# Altium schematic sheet Supplies.SchDoc(Supplies)
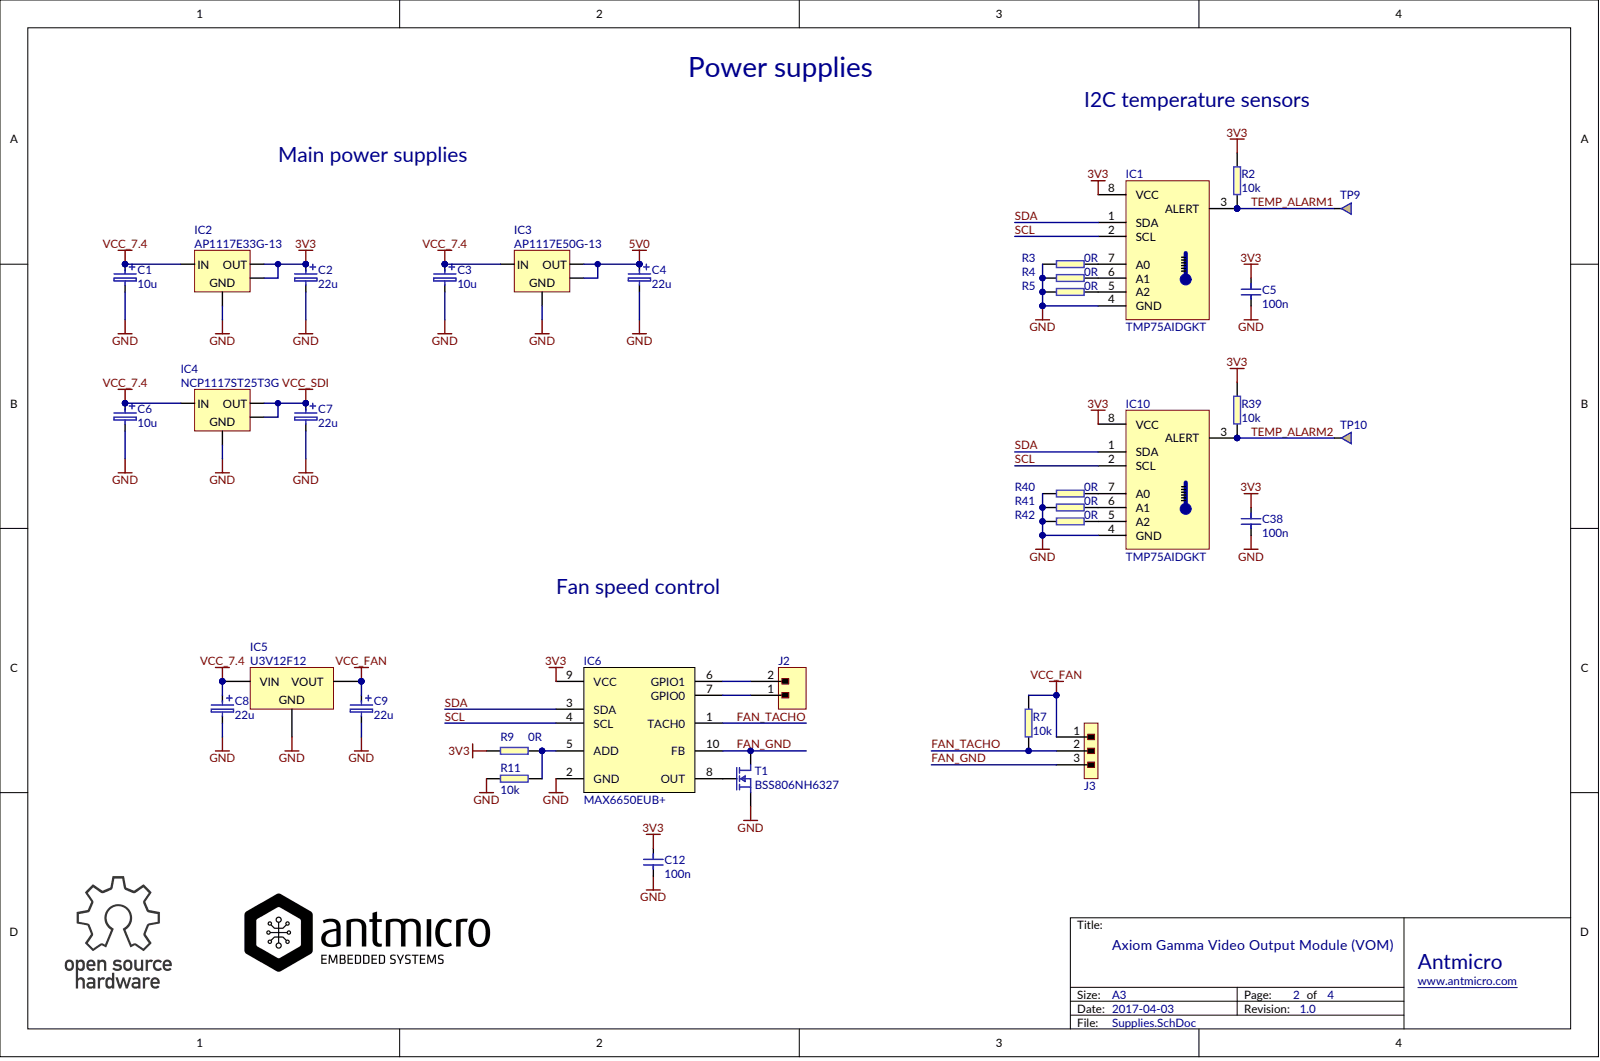
[diagram p0: Supplies.SchDoc]

## Parts
C1  10u
C2  22u
C3  10u
C4  22u
C5  100n
C6  10u
C7  22u
C8  22u
C9  22u
C12  100n
C38  100n
IC1  TMP75AIDGKT
IC2  AP1117E33G-13
IC3  AP1117E50G-13
IC4  NCP1117ST25T3G
IC5  U3V12F12
IC6  MAX6650EUB+
IC10  TMP75AIDGKT
J2  MH_1X02_2.54  (value not drawn)
J3  Molex 022232031  (value not drawn)
R2  10k
R3  0R
R4  0R
R5  0R
R7  10k
R9  0R
R11  10k
R39  10k
R40  0R
R41  0R
R42  0R
T1  BSS806NH6327
TP9  Testpad  (value not drawn)
TP10  Testpad  (value not drawn)

## Nets
3V3: C2.1 C5.1 C12.1 C38.1 IC1.8(VCC) IC2.2(OUT) IC2.4 IC6.9(VCC) IC10.8(VCC) R2.2 R9.1 R39.2
5V0: C4.1 IC3.2(OUT) IC3.4
GND: C1.2 C2.2 C3.2 C4.2 C5.2 C6.2 C7.2 C8.2 C9.2 C12.2 C38.2 IC1.4(GND) IC2.1 IC3.1 IC4.1 IC5.2 IC6.2(GND) IC10.4(GND) R3.2 R4.2 R5.2 R11.1 R40.2 R41.2 R42.2 T1.2
VCC_7.4: C1.1 C3.1 C6.1 C8.1 IC2.3(IN) IC3.3(IN) IC4.3(IN) IC5.1
VCC_FAN: C9.1 IC5.3 J3.1 R7.2
VCC_SDI: C7.1 IC4.2(OUT) IC4.4(GND)
FAN_GND: IC6.10(FB) J3.3 T1.3
FAN_TACHO: IC6.1(TACH0) J3.2 R7.1
SCL: IC1.2(SCL) IC6.4(SCL) IC10.2(SCL)
SDA: IC1.1(SDA) IC6.3(SDA) IC10.1(SDA)
TEMP_ALARM1: IC1.3(ALERT) R2.1 TP9.1
TEMP_ALARM2: IC10.3(ALERT) R39.1 TP10.1
NetIC1_5: IC1.5(A2) R5.1
NetIC1_6: IC1.6(A1) R4.1
NetIC1_7: IC1.7(A0) R3.1
NetIC6_5: IC6.5(ADD) R9.2 R11.2
NetIC6_6: IC6.6(GPIO1) J2.2
NetIC6_7: IC6.7(GPIO0) J2.1
NetIC6_8: IC6.8(OUT) T1.1
NetIC10_5: IC10.5(A2) R42.1
NetIC10_6: IC10.6(A1) R41.1
NetIC10_7: IC10.7(A0) R40.1

## Library data of the parts
C1 C3 C6 = (no symbol name): Part Number: C_10u_KEMET_C; Manufacturer: KEMET; Part Symbol: T491C106K025AT; Value: 10u
C2 C4 C7-C9 = (no symbol name): Part Number: C_22u_KEMET_C; Manufacturer: KEMET; Part Symbol: T491C226K025AT; Value: 22u
C5 C12 C38 = (no symbol name): Part Number: C_100n_0402; Manufacturer: MULTICOMP; Part Symbol: MC0402X104M100CT; Value: 100n
IC1 IC10 = (no symbol name): Manufacturer: TEXAS INSTRUMENTS; Part Number: TMP75AIDGKT; Value: TMP75AIDGKT; Part Symbol: TMP75AIDGKT
IC2 IC3 = (no symbol name): Manufacturer: DIODES INC.
  IC2: Value: AP1117E33G-13; Part Number: AP1117E33G-13; Part Symbol: AP1117E33G-13
  IC3: Value: AP1117E50G-13; Part Number: AP1117E50G-13; Part Symbol: AP1117E50G-13
IC4 = (no symbol name): Manufacturer: ON Semiconductor; Value: NCP1117ST25T3G; Part Number: NCP1117ST25T3G; Part Symbol: NCP1117ST25T3G
IC5 = (no symbol name): Manufacturer: TME; Part Symbol: POLOLU-2117 / POLOLU-2117; Part Number: U3V12F12; Value: U3V12F12
IC6 = (no symbol name): Manufacturer: MAXIM INTEGRATED; Part Number: MAX6650EUB+; Value: MAX6650EUB+; Part Symbol: MAX6650EUB+
J2 = (no symbol name): Manufacturer: MULTICOMP; Part Symbol: 2211S-02G; Part Number: MH_1X02_2.54; Value: MH_1X02_2.54
J3 = (no symbol name): Part Number: molex_2223-2031; Value: Molex 022232031; Manufacturer: Molex; Part Symbol: 22-23-2031
R2 R7 R39 = (no symbol name): Part Number: R_10k_0402; Manufacturer: MULTICOMP; Part Symbol: MCMR04X1002FTL; Value: 10k
R3-R5 R9 R40-R42 = (no symbol name): Part Number: R_0R_0603; Manufacturer: BOURNS; Part Symbol: CR0603-J/-000ELF; Value: 0R
R11 = (no symbol name): Part Number: R_10k_0603; Manufacturer: BOURNS; Part Symbol: CR0603-JW-103ELF; Value: 10k
T1 = (no symbol name): Manufacturer: INFINEON; Part Symbol: BSS806NH6327XTSA1; Value: BSS806NH6327; Part Number: BSS806NH6327
TP9 TP10 = (no symbol name): Manufacturer: PCB ONLY; Part Number: Testpad; Part Symbol: PCB ONLY
